# Altium schematic sheet ManipBoard.SchDoc(ManipBoard)
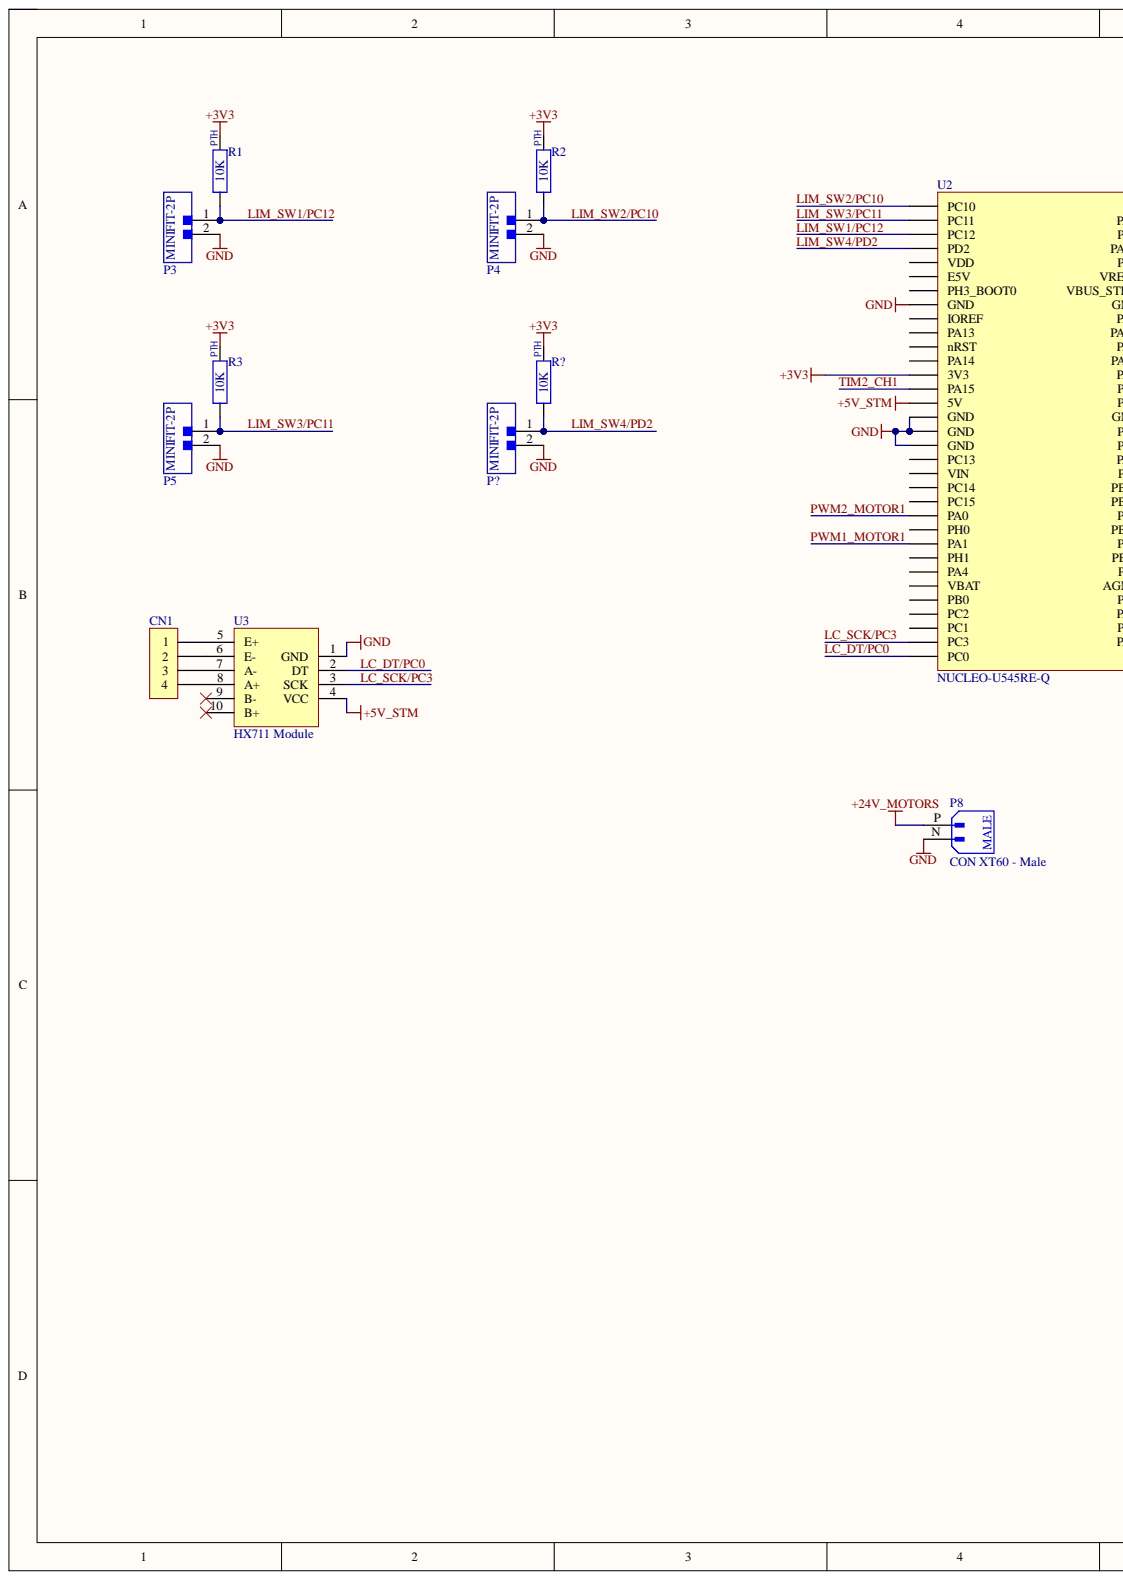
[diagram p0: ManipBoard.SchDoc, left]
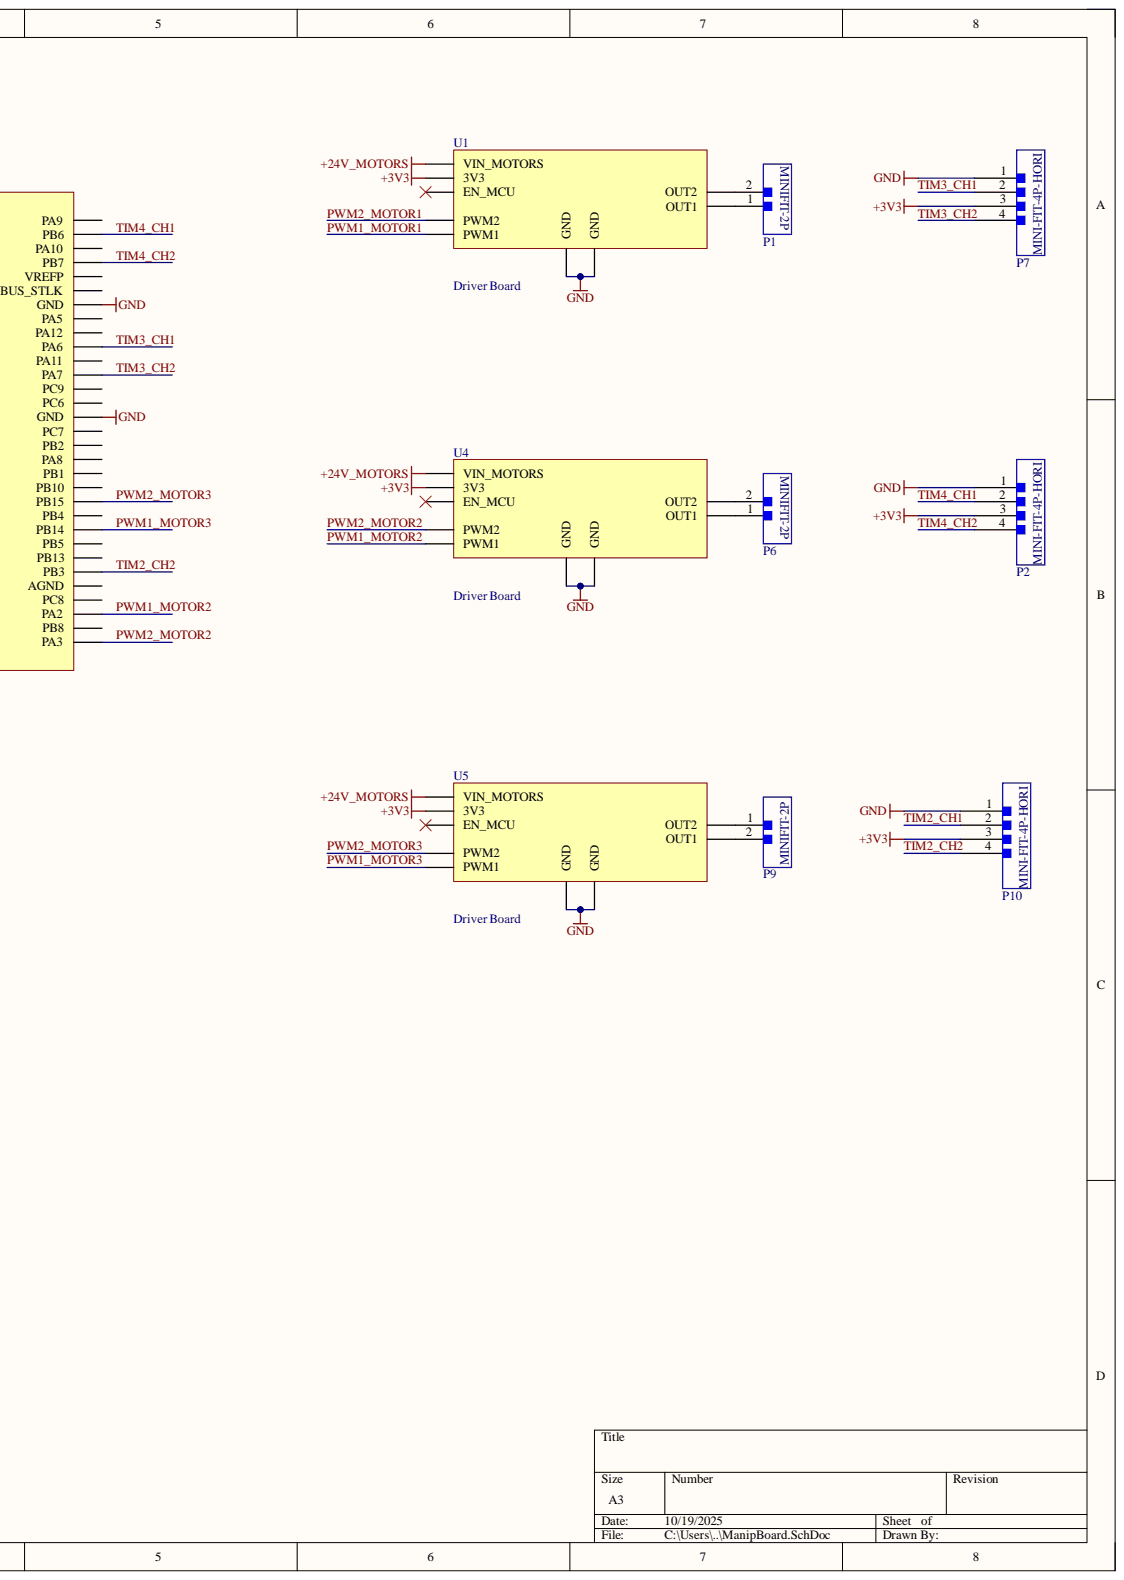
[diagram p1: ManipBoard.SchDoc, right]

## Parts
CN1  CON HEADER 2.54mm 1x4  [CON HEADER 2.54mm 1x4]  (value not drawn)
P1  CON MINI FIT 2P - 1x2  [CON MINI FIT 2 POS - 1x2 - 90DG]  (value not drawn)
P2  CON MINI FIT 4P - 2x2 - HORIZONTAL  [CON MINI FIT 4 POS - 2x2 - 90DG]  (value not drawn)
P3  CON MINI FIT 2P - 1x2  [CON MINI FIT 2 POS - 1x2 - 90DG]  (value not drawn)
P4  CON MINI FIT 2P - 1x2  [CON MINI FIT 2 POS - 1x2 - 90DG]  (value not drawn)
P5  CON MINI FIT 2P - 1x2  [CON MINI FIT 2 POS - 1x2 - 90DG]  (value not drawn)
P6  CON MINI FIT 2P - 1x2  [CON MINI FIT 2 POS - 1x2 - 90DG]  (value not drawn)
P7  CON MINI FIT 4P - 2x2 - HORIZONTAL  [CON MINI FIT 4 POS - 2x2 - 90DG]  (value not drawn)
P8  CON XT60 - Male  [CON XT60 MALE]
P9  CON MINI FIT 2P - 1x2  [CON MINI FIT 2 POS - 1x2 - 90DG]  (value not drawn)
P10  CON MINI FIT 4P - 2x2 - HORIZONTAL  [CON MINI FIT 4 POS - 2x2 - 90DG]  (value not drawn)
P?  CON MINI FIT 2P - 1x2  [CON MINI FIT 2 POS - 1x2 - 90DG]  (value not drawn)
R1  10K  [RES PTH]
R2  10K  [RES PTH]
R3  10K  [RES PTH]
R?  10K  [RES PTH]
U1  Driver Board  [Driver Board]
U2  NUCLEO-U545RE-Q  [NUCLEO64]
U3  HX711 Module  [HX711 XFW]
U4  Driver Board  [Driver Board]
U5  Driver Board  [Driver Board]

## Nets
+3V3: P2.3 P7.3 P10.3 R1.1 R2.1 R3.1 R?.1 U1.2(3V3) U2.CN7_16, CN6_4(3V3) U4.2(3V3) U5.2(3V3)
+5V_STM: U2.CN7_18(5V) U3.4(VCC)
+24V_MOTORS: P8.P U1.3, 4, 5, 6(VIN_MOTORS) U4.3, 4, 5, 6(VIN_MOTORS) U5.3, 4, 5, 6(VIN_MOTORS)
GND: P2.1 P3.2 P4.2 P5.2 P7.1 P8.N P10.1 P?.2 U1.9, 10(GND) U1.23, 24, 25, 26(GND) U2.CN7_8(GND) U2.CN7_19(GND) U2.CN7_20(GND) U2.CN7_22(GND) U2.CN10_9(GND) U2.CN10_20(GND) U3.1(GND) U4.9, 10(GND) U4.23, 24, 25, 26(GND) U5.9, 10(GND) U5.23, 24, 25, 26(GND)
LC_DT/PC0: U2.CN7_38(PC0) U3.2(DT)
LC_SCK/PC3: U2.CN7_37(PC3) U3.3(SCK)
LIM_SW1/PC12: P3.1 R1.2 U2.CN7_3(PC12)
LIM_SW2/PC10: P4.1 R2.2 U2.CN7_1(PC10)
LIM_SW3/PC11: P5.1 R3.2 U2.CN7_2(PC11)
LIM_SW4/PD2: P?.1 R?.2 U2.CN7_4(PD2)
PWM1_MOTOR1: U1.7(PWM1) U2.CN7_30, CN8_2(PA1)
PWM1_MOTOR2: U2.CN10_35, CN9_2(PA2) U4.7(PWM1)
PWM1_MOTOR3: U2.CN10_28(PB14) U5.7(PWM1)
PWM2_MOTOR1: U1.8(PWM2) U2.CN7_28, CN8_1(PA0)
PWM2_MOTOR2: U2.CN10_37,CN9_1(PA3) U4.8(PWM2)
PWM2_MOTOR3: U2.CN10_26(PB15) U5.8(PWM2)
TIM2_CH1: P10.2 U2.CN7_17(PA15)
TIM2_CH2: P10.4 U2.CN10_31(PB3)
TIM3_CH1: P7.2 U2.CN10_13, CN5_5(PA6)
TIM3_CH2: P7.4 U2.CN10_15, CN5_7(PA7)
TIM4_CH1: P2.2 U2.CN10_3, CN5_10(PB6)
TIM4_CH2: P2.4 U2.CN10_5, CN5_9(PB7)
NetCN1_1: CN1.1 U3.5(E+)
NetCN1_2: CN1.2 U3.6(E-)
NetCN1_3: CN1.3 U3.7(A-)
NetCN1_4: CN1.4 U3.8(A+)
NetP1_1: P1.1 U1.27, 28(OUT1)
NetP1_2: P1.2 U1.21, 22(OUT2)
NetP6_1: P6.1 U4.27, 28(OUT1)
NetP6_2: P6.2 U4.21, 22(OUT2)
NetP9_1: P9.1 U5.21, 22(OUT2)
NetP9_2: P9.2 U5.27, 28(OUT1)
NetU2_CN10_33, CN9_3: U2.CN10_33, CN9_3(PC8)

Not connected: U1 1(EN_MCU); U2 CN7_5(VDD) CN7_6(E5V) CN7_7(PH3_BOOT0) CN7_12(IOREF) CN7_13(PA13) CN7_14(nRST) CN7_15(PA14) CN7_23(PC13) CN7_24(VIN) CN7_25(PC14) CN7_27(PC15) CN7_29(PH0) CN7_31(PH1) CN7_32(PA4) CN7_33(VBAT) CN7_34(PB0) CN7_35(PC2) CN7_36(PC1) CN10_2(PA9) CN10_4(PA10) CN10_7(VREFP) CN10_8(VBUS_STLK) CN10_11(PA5) CN10_12(PA12) CN10_14(PA11) CN10_17(PC9) CN10_19(PC6) CN10_21(PC7) CN10_22(PB2) CN10_23(PA8) CN10_24(PB1) CN10_25(PB10) CN10_27(PB4) CN10_29(PB5) CN10_30(PB13) CN10_32(AGND) CN10_36(PB8); U3 9(B-) 10(B+); U4 1(EN_MCU); U5 1(EN_MCU)

## Library data of the parts
P1 P3-P6 P9 P? = CON MINI FIT 2P - 1x2: CONECTOR - MINI-FIT 2 pinos - 180/90 graus - 4.2mm; Component Kind: Standard; Library Name: My_Lib.IntLib
P2 P7 P10 = CON MINI FIT 4P - 2x2 - HORIZONTAL: CONECTOR - MINI-FIT 4 pinos - 90 graus - 4.2mm; Component Kind: Standard; Library Name: My_Lib.IntLib
R1-R3 R? = RES PTH - Gen.: Generic PTH resistor for general purpose; Component Kind: Standard; Library Name: My_Lib.IntLib; value: 10K
U1 U4 U5 = Driver Board: IC Module - Driver Board STSPIN958; Component Kind: Standard; Library Name: My_Lib.IntLib
U3 = HX711 Module: HX711 XFW module; Component Kind: Standard; Library Name: My_Lib.IntLib
CN1 = CON HEADER 2.54mm 1x4: Component Kind: Standard; Library Name: My_Lib.IntLib
P8 = CON XT60 - Male: Component Kind: Standard; Library Name: My_Lib.IntLib
U2 = NUCLEO-U545RE-Q: Component Kind: Standard; Library Name: My_Lib.IntLib
